# Altium schematic sheet Stage0B_current_sense.SchDoc
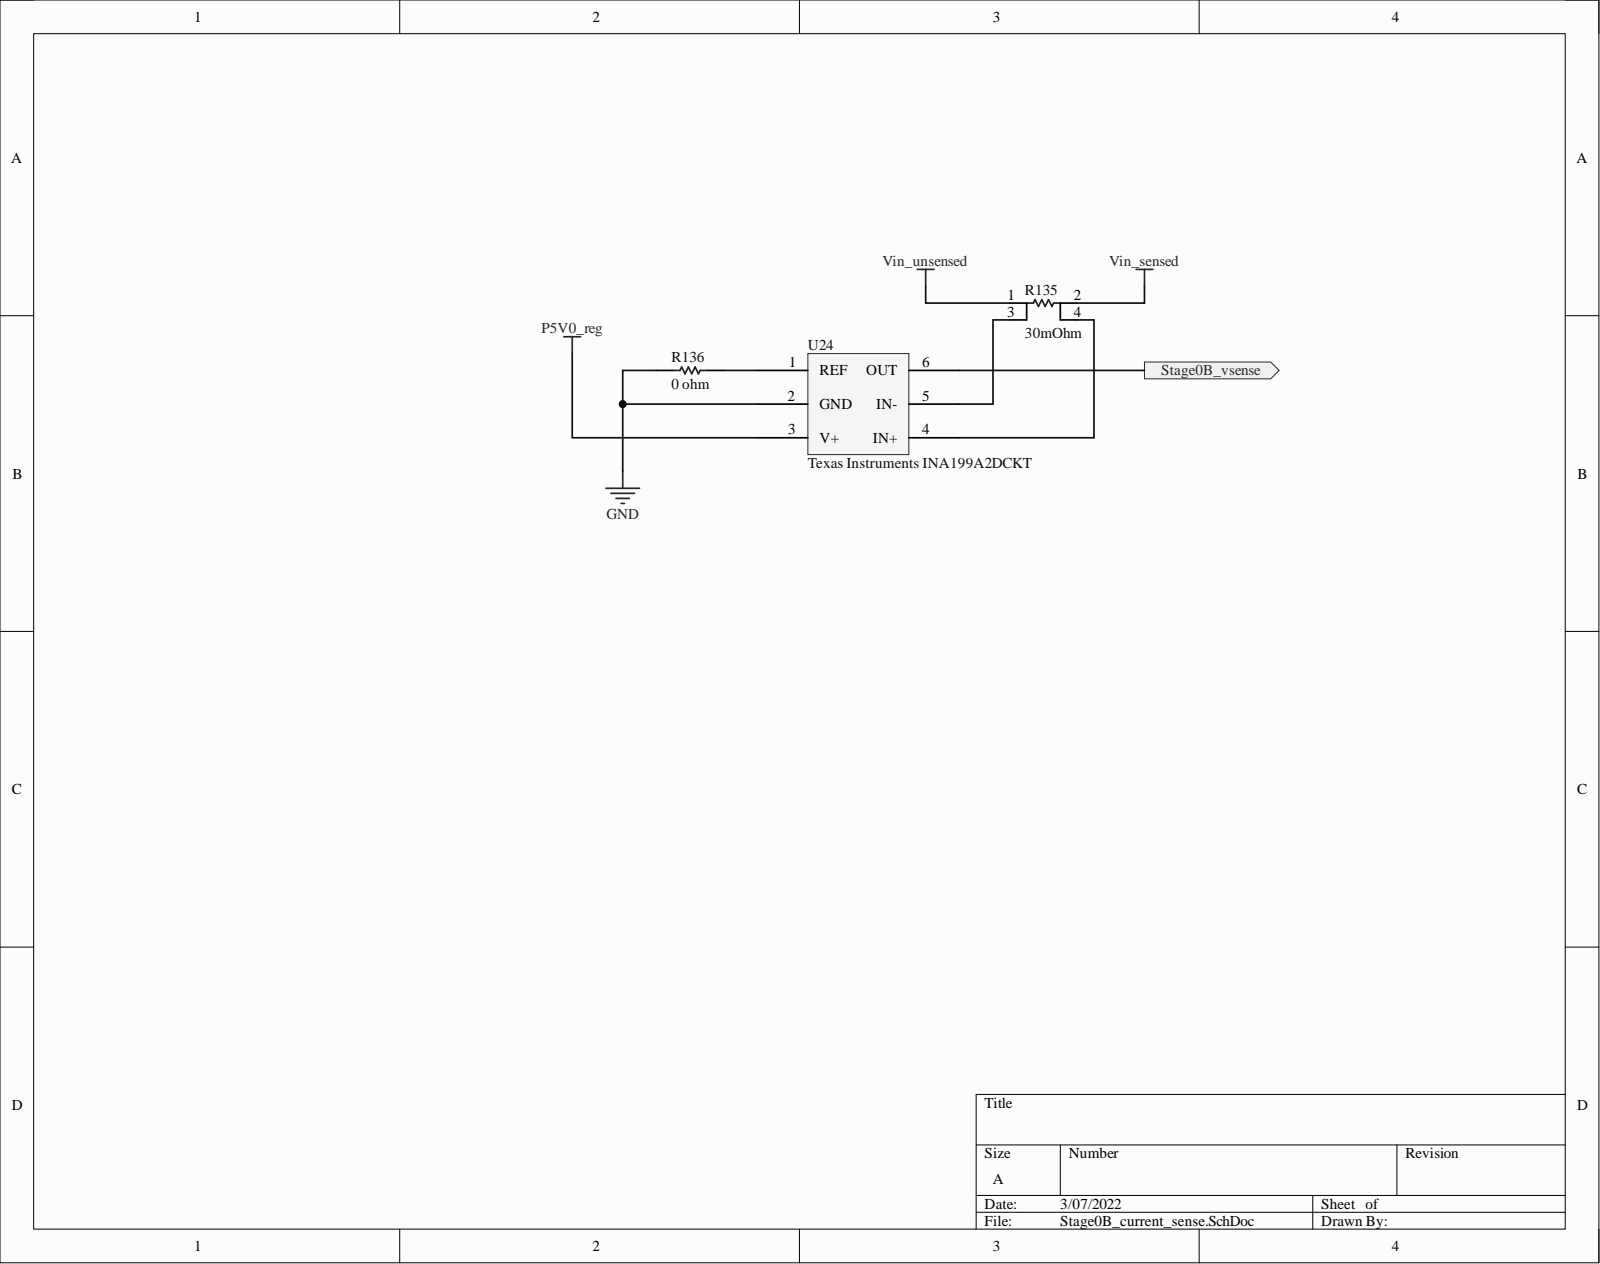
[diagram p0: Stage0B_current_sense.SchDoc]

## Parts
R135  30mOhm  [PCB-e4z1ou8eehx9zfei5nig-1]
R136  0 ohm  [RESC2013X60X35NL10T20]
U24  Texas Instruments INA199A2DCKT  Manufacturer Part Number: INA199A2DCKT  [PCB-vwo9vyn9lj2uhzgmk5fx-1]

## Ports
Stage0B_vsense

## Nets
GND: R136.1 U24.2(GND)
P5V0_reg: U24.3(V+)
Vin_sensed: R135.2
Vin_unsensed: R135.1
Stage0B_vsense: U24.6(OUT) ; port Stage0B_vsense
NetR135_3: R135.3 U24.5(IN-)
NetR135_4: R135.4 U24.4(IN+)
NetR136_2: R136.2 U24.1(REF)

## Library data of the parts
R135 = CMP-41418819abb09e86-1: Res Metal Alloy 1206 0.03 Ohm 0.5% 0.5W(1/2W) ±50ppm/C Epoxy Resin Pad SMD T/R; Manufacturer Part Number: LVK12R030DER; Case/Package (SI): 3216; Power Rating: 500 mW; Resistance: 30.0 mΩ; Temperature Coefficient: -50.0 ppm/°C to 50.0 ppm/°C; Case/Package: 3216, 1206; Component Kind: Standard; Library Name: My Personal Space; Mounting Style: Surface Mount; Number of Pins: 2; Octopart URL: https://octopart.com/lvk12r030der-ohmite-5427095; OctopartID: 41418819abb09e86; Operating Temperature: -40.0 °C to 125 °C; Packaging: Tape & Reel (TR), Cut Tape (CT); Resistance Tolerance: ±0.5%; RoHS: Compliant
R136 = CMP-5eab975428dd816c-2: Res Thick Film 0805 0 Ohm Jumper Molded SMD T/R; Manufacturer Part Number: CRG0805ZR; Case/Package (SI): 2012; ELV Compliant: Compliant; Power Rating: 125 mW; Resistance: 0.00 Ω; Case/Package: 0805; Component Kind: Standard; Library Name: My Personal Space; Lifecycle Status: Active; Mounting Style: Surface Mount; Octopart URL: https://octopart.com/crg0805zr-te+connectivity-16077584; OctopartID: 5eab975428dd816c; Packaging: Tape & Reel (TR); RoHS: Compliant
U24 = CMP-476a8f7b8eaa98ad-1: 26-V, Bi-Directional, Zero-Drift, Low- or High-Side, Voltage Output Current Shunt Monitor 6-SC70 -40 to 125; Bandwidth: 30.0 kHz; Lead-Free Status: Lead Free; Supply Current: 65.0 µA; Supply Voltage (DC): 2.70 V (min); Component Kind: Standard; Library Name: My Personal Space; Lifecycle Status: Active; Number of Pins: 6; Octopart URL: http://octopart.com/ina199a2dckt-texas+instruments-18607270; OctopartID: 476a8f7b8eaa98ad; REACH SVHC Compliance: No SVHC; RoHS: Compliant
